# Altium schematic sheet ARK_RTK_Base.SchDoc(ARK_RTK_Base)
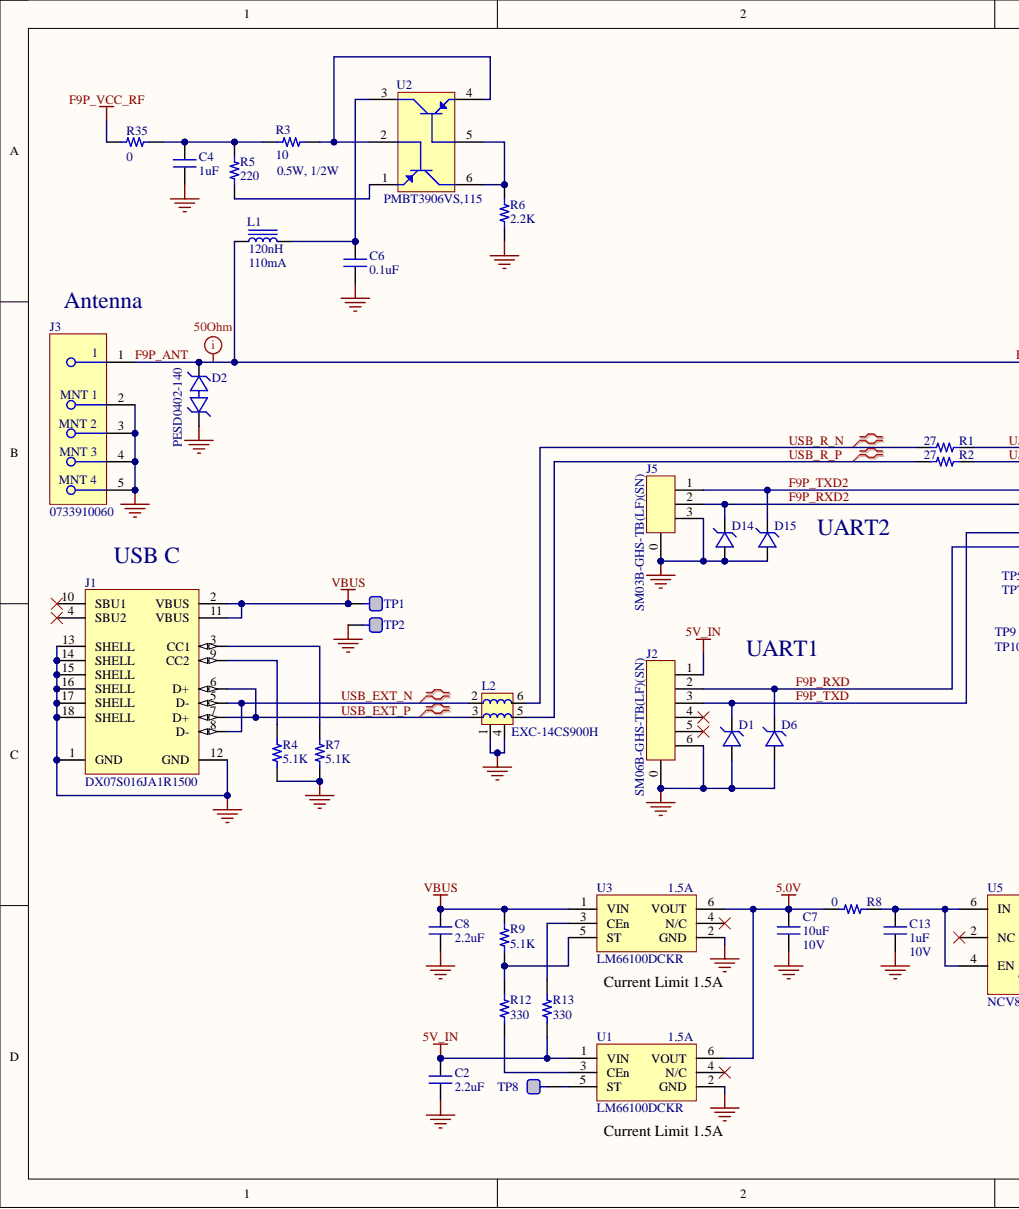
[diagram p0: ARK_RTK_Base.SchDoc, left]
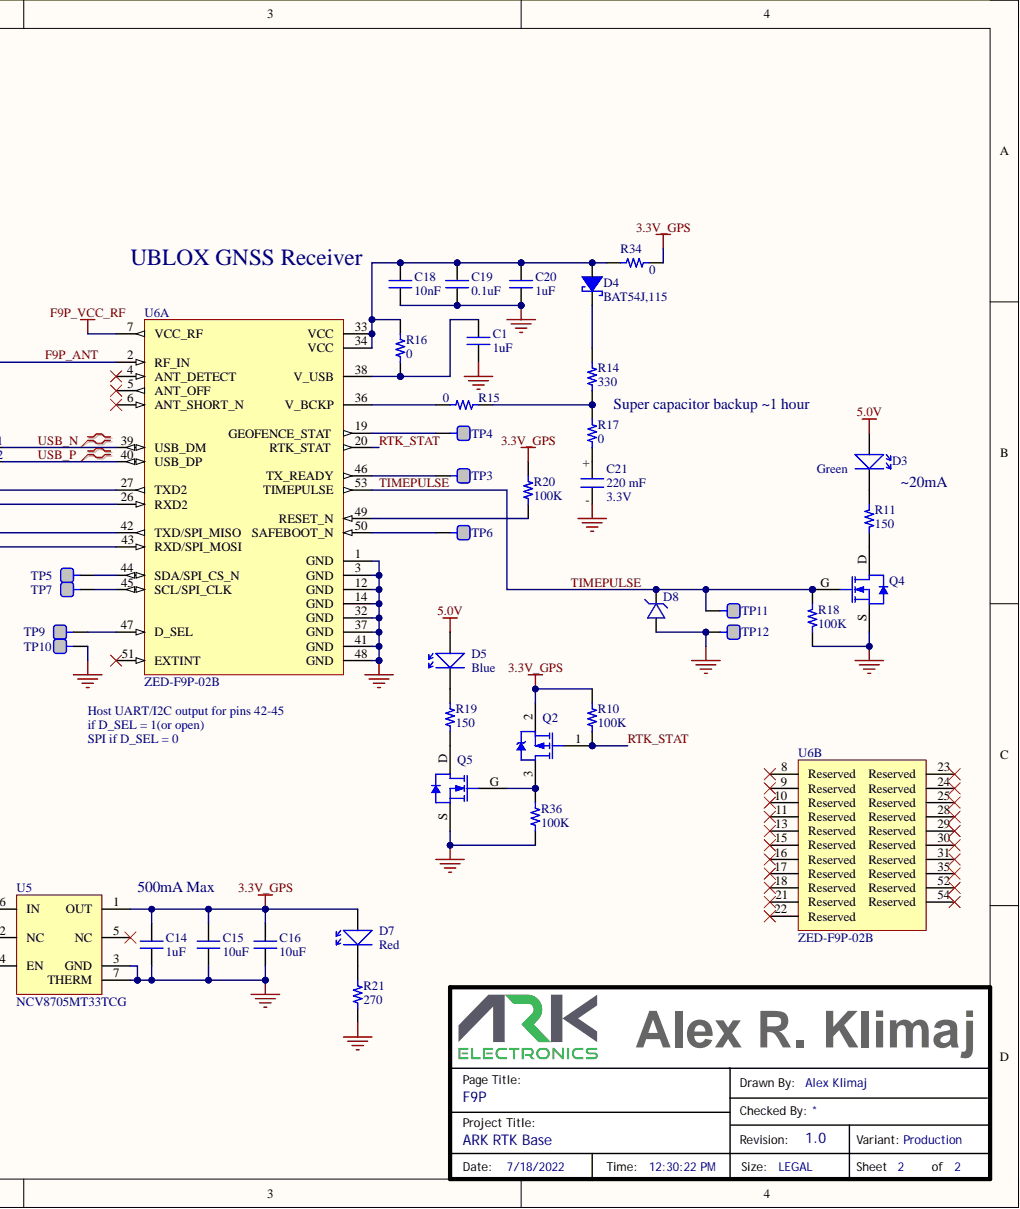
[diagram p1: ARK_RTK_Base.SchDoc, right]

## Parts
C1  1uF  [CAP 0402/1005]
C2  2.2uF  [CAP 0402/1005]
C4  1uF  [CAP 0402/1005]
C6  value 0.1uF  [CAP 0402/1005]  (hidden comment: Capacitor)
C7  10uF  Voltage_Rating: 10V  [CAP 0402/1005]
C8  2.2uF  [CAP 0402/1005]
C13  1uF  Voltage_Rating: 10V  [CAP 0402/1005]
C14  1uF  [CAP 0402/1005]
C15  10uF  [CAP 0402/1005]
C16  10uF  [CAP 0402/1005]
C18  10nF  [CAP 0402/1005]
C19  value 0.1uF  [CAP 0402/1005]  (hidden comment: Capacitor)
C20  1uF  [CAP 0402/1005]
C21  220 mF  Voltage_Rating: 3.3V  [DSK-3R3H224U-HL]
D1  TVS Diode  [SOD-923]  (value not drawn)
D2  PESD0402-140  [DIOC1005X04N 0402]
D3  LTST-C191KGKT  [LTST-C191TBKT]  (value not drawn)
D4  BAT54J,115  [SOD323]
D5  LTST-C194TBKT  [LTST-C191TBKT]  (value not drawn)
D6  TVS Diode  [SOD-923]  (value not drawn)
D7  LTST-C190KRKT  [LTST-C191TBKT]  (value not drawn)
D8  TVS Diode  [SOD-923]  (value not drawn)
D14  TVS Diode  [SOD-923]  (value not drawn)
D15  TVS Diode  [SOD-923]  (value not drawn)
J1  DX07S016JA1R1500  [CQ-USB-C16PSMT0]
J2  value SM06B-GHS-TB(LF)(SN)  Mfr Part Number: SM06B-GHS-TB(LF)(SN)  [SM06B-GHS]
J3  0733910060  [MOLEX_73391-0060]
J5  SM03B-GHS-TB(LF)(SN)  [SM03B-GHS]
L1  120nH  Current_Rating: 110mA  [Ind 0402/1005]
L2  EXC-14CS900H  [EXC-14CS900H]
Q2  INF-PEMOS-GSD-3-B  [INF-PG-SOT-323_L]  (value not drawn)
Q4  DMN53D0L-7  [SOT23 DIODES]  (value not drawn)
Q5  DMN53D0L-7  [SOT23 DIODES]  (value not drawn)
R1  27  [RESC0402(1005)_N]
R2  27  [RESC0402(1005)_N]
R3  10  Power_Rating: 0.5W, 1/2W  [C1210_M]
R4  value 5.1K  [RESC0402(1005)_N]  (hidden comment: Resistor)
R5  220  [RESC0402(1005)_N]
R6  2.2K  [RESC0402(1005)_N]
R7  value 5.1K  [RESC0402(1005)_N]  (hidden comment: Resistor)
R8  0  [RESC0402(1005)_N]
R9  value 5.1K  [RESC0402(1005)_N]  (hidden comment: Resistor)
R10  100K  [RESC0402(1005)_N]
R11  150  [RESC0402(1005)_N]
R12  value 330  [RESC0402(1005)_N]  (hidden comment: Resistor)
R13  value 330  [RESC0402(1005)_N]  (hidden comment: Resistor)
R14  330  [RESC0402(1005)_N]
R15  0  [RESC0402(1005)_N]
R16  0  [RESC0402(1005)_N]
R17  0  [RESC0402(1005)_N]
R18  100K  [RESC0402(1005)_N]
R19  150  [RESC0402(1005)_N]
R20  100K  [RESC0402(1005)_N]
R21  270  [RESC0402(1005)_N]
R34  0  [RESC0402(1005)_N]
R35  0  [RESC0402(1005)_N]
R36  100K  [RESC0402(1005)_N]
TP1  TEST_PAD  [TEST_PAD]  (value not drawn)  (no BOM)
TP2  TEST_PAD  [TEST_PAD]  (value not drawn)  (no BOM)
TP3  TEST_PAD  [TEST_PAD]  (value not drawn)  (no BOM)
TP4  TEST_PAD  [TEST_PAD]  (value not drawn)  (no BOM)
TP5  TEST_PAD  [TEST_PAD]  (value not drawn)  (no BOM)
TP6  TEST_PAD  [TEST_PAD]  (value not drawn)  (no BOM)
TP7  TEST_PAD  [TEST_PAD]  (value not drawn)  (no BOM)
TP8  TEST_PAD  [TEST_PAD]  (value not drawn)  (no BOM)
TP9  TEST_PAD  [TEST_PAD]  (value not drawn)  (no BOM)
TP10  TEST_PAD  [TEST_PAD]  (value not drawn)  (no BOM)
TP11  TEST_PAD  [TEST_POINT]  (value not drawn)  (no BOM)
TP12  TEST_PAD  [TEST_POINT]  (value not drawn)  (no BOM)
U1  LM66100  value LM66100DCKR  Current_Rating: 1.5A  Mfr Part Number: LM66100DCKR  [SOT65P210X110-6N]
U2  PMBT3906VS,115  [SOT666]
U3  LM66100  value LM66100DCKR  Current_Rating: 1.5A  Mfr Part Number: LM66100DCKR  [SOT65P210X110-6N]
U5  NCV8705MT33TCG  [WDFN6 2x2, 0.65P]
U6  ZED-F9P-02B  [Ublox ZED-F9P]  (parts A,B)

## Nets
3.3V_GPS: C14.2 C15.2 C16.2 D7.2 Q2.2 R10.2 R20.2 R34.1 U5.1(OUT)
5.0V: C2.2 C7.2 D3.2 D5.2 J2.1 R8.1 R13.2 U1.1(VIN) U1.6(VOUT) U3.6(VOUT)
F9P_VCC_RF: R35.1 U6.7(VCC_RF)
GND: C1.1 C2.1 C4.1 C6.1 C7.1 C8.1 C13.1 C14.1 C15.1 C16.1 C18.1 C19.1 C20.1 C21.- D1.2 D2.2 D6.2 D8.2 D14.2 D15.2 J1.1(GND) J1.12(GND) J1.13(SHELL) J1.14(SHELL) J1.15(SHELL) J1.16(SHELL) J1.17(SHELL) J1.18(SHELL) J2.0 J2.6 J3.2 J3.3 J3.4 J3.5 J5.0 J5.3 L2.1 L2.4 Q4.S Q5.S R4.2 R6.1 R7.2 R18.1 R21.1 R36.1 TP2.1 TP10.1 TP12.1 U1.2(GND) U3.2(GND) U5.3(GND) U5.7(THERM) U6.1(GND) U6.3(GND) U6.12(GND) U6.14(GND) U6.32(GND) U6.37(GND) U6.41(GND) U6.48(GND)
VBUS: C8.2 J1.2(VBUS) J1.11(VBUS) R9.1 TP1.1 U3.1(VIN)
F9P_ANT: D2.1 J3.1 L1.1 U6.2(RF_IN)
F9P_RXD: D6.1 J2.2 U6.43(RXD/SPI_MOSI)
F9P_RXD2: D14.1 J5.2 U6.26(RXD2)
F9P_TXD: D1.1 J2.3 U6.42(TXD/SPI_MISO)
F9P_TXD2: D15.1 J5.1 U6.27(TXD2)
RTK_STAT: Q2.1 R10.1 U6.20(RTK_STAT)
TIMEPULSE: D8.1 Q4.G R18.2 TP11.1 U6.53(TIMEPULSE)
USB_EXT_N: J1.5(D-) J1.8(D-) L2.2
USB_EXT_P: J1.6(D+) J1.7(D+) L2.3
USB_N: R1.2 U6.39(USB_DM)
USB_P: R2.2 U6.40(USB_DP)
USB_R_N: L2.6 R1.1
USB_R_P: L2.5 R2.1
NetC1_2: C1.2 R16.1 U6.38(V_USB)
NetC4_2: C4.2 R3.2 R5.2 R35.2
NetC6_2: C6.2 L1.2 U2.3
NetC13_2: C13.2 R8.2 U5.4(EN) U5.6(IN)
NetC18_2: C18.2 C19.2 C20.2 D4.2 R16.2 R34.2 U6.33(VCC) U6.34(VCC)
NetC21_+: C21.+ R17.1
NetD3_1: D3.1 R11.2
NetD4_1: D4.1 R14.2
NetD5_1: D5.1 R19.2
NetD7_1: D7.1 R21.2
NetJ1_3: J1.3(CC1) R7.1
NetJ1_9: J1.9(CC2) R4.1
NetQ2_3: Q2.3 Q5.G R36.2
NetQ4_D: Q4.D R11.1
NetQ5_D: Q5.D R19.1
NetR3_1: R3.1 U2.2 U2.4
NetR5_1: R5.1 U2.1
NetR6_2: R6.2 U2.5 U2.6
NetR9_2: R9.2 R12.1 U3.5(ST)
NetR12_2: R12.2 U1.3(CEn)
NetR13_1: R13.1 U3.3(CEn)
NetR14_1: R14.1 R15.2 R17.2
NetR15_1: R15.1 U6.36(V_BCKP)
NetR20_1: R20.1 U6.49(RESET_N)
NetTP3_1: TP3.1 U6.46(TX_READY)
NetTP4_1: TP4.1 U6.19(GEOFENCE_STAT)
NetTP5_1: TP5.1 U6.44(SDA/SPI_CS_N)
NetTP6_1: TP6.1 U6.50(SAFEBOOT_N)
NetTP7_1: TP7.1 U6.45(SCL/SPI_CLK)
NetTP8_1: TP8.1 U1.5(ST)
NetTP9_1: TP9.1 U6.47(D_SEL)

Not connected: J1 4(SBU2) 10(SBU1); J2 4 5; U1 4(N/C); U3 4(N/C); U5 2(NC) 5(NC); U6 4(ANT_DETECT) 5(ANT_OFF) 6(ANT_SHORT_N) 8(Reserved) 9(Reserved) 10(Reserved) 11(Reserved) 13(Reserved) 15(Reserved) 16(Reserved) 17(Reserved) 18(Reserved) 21(Reserved) 22(Reserved) 23(Reserved) 24(Reserved) 25(Reserved) 28(Reserved) 29(Reserved) 30(Reserved) 31(Reserved) 35(Reserved) 51(EXTINT) 52(Reserved) 54(Reserved)

## Library data of the parts
C1 C4 C14 C20 = 30652: SMT Capacitor; Manufacturer: Samsung Electro-Mechanics; Mfr Part Description: CAP CER 1uF ±10% 10V X5R 0402 (1005 Metric); Mfr Part Number: CL05A105KP5NNNC; Database Table Name: Parts; Dielectric: X5R; Tolerance: ±10%; Updated By: aklimaj; Voltage_Rating: 10V; Component Kind: Standard; ComponentLink1Description: Datasheet; ComponentLink1URL: http://www.samsungsem.com/kr/support/product-search/mlcc/CL05A105KP5NNNC.jsp; ComponentLink2Description: Supplier Part Details; ComponentLink2URL: https://www.digikey.com/product-detail/en/0/1276-1076-1-ND; Created_Date: 2019/11/21 13:38:55; ID: 30652; Library Name: Parts.DbLib; LifeCycle: New; Package_Case: 0402 (1005 Metric); Part_Type: Capacitor; RoHS: Yes; Supplier: Digi-Key; Supplier Part Number: 1276-1076-1-ND; Temperature Range: -55C to +85C; Updated_Date: 2020/08/31 12:38:02; Value: 1uF; Created By: aklimaj
C2 C8 = 30151: SMT Capacitor; Manufacturer: Murata; Manufacturer Alt 1: Taiyo Yuden; Mfr Part Description: CAP CER 2.2uF ±20% 10V X5R 0402 (1005 Metric); Mfr Part Number: GRM155R61A225ME95D; Mfr Part Number Alt 1: LMK105BJ225MV-F; Database Table Name: Parts; Dielectric: X5R; Tolerance: ±20%; Updated By: aklimaj; Voltage_Rating: 10V; Component Kind: Standard; ComponentLink1Description: Datasheet; ComponentLink1URL: http://search.murata.co.jp/Ceramy/image/img/A01X/partnumbering_e_01.pdf; ComponentLink2Description: Supplier Part Details; ComponentLink2URL: https://www.digikey.com/product-detail/en/murata-electronics-north-america/GRM155R61A225ME95D/490-10452-2-ND/5027537; Created_Date: 2017/11/02 14:41:38; ID: 30151; Library Name: Parts.DbLib; LifeCycle: New; Package_Case: 0402 (1005 Metric); Part_Type: Capacitor; RoHS: Yes; Supplier: Digi-Key; Supplier Part Number: 490-10452-2-ND; Temperature Range: -55C to +85C; Updated_Date: 2021/09/10 21:38:25; Value: 2.2uF; Created By: aklimaj
C6 C19 = 30007: SMT Capacitor; Manufacturer: Walsin Technology Corporation; Mfr Part Description: CAP CER 0.1uF ±10% 25V X5R 0402 (1005 Metric); Mfr Part Number: 0402X104K250CT; Database Table Name: Parts; Dielectric: X5R; Tolerance: ±10%; Updated By: aklimaj; Voltage_Rating: 25V; Component Kind: Standard; ComponentLink1Description: Datasheet; ComponentLink1URL: http://www.passivecomponent.com/wp-content/uploads/2018/10/MLCC.pdf; ComponentLink2Description: Supplier Part Details; ComponentLink2URL: https://www.digikey.com/products/en?keywords=0402X104K250CT; Created_Date: 2017/07/11 17:31:38; ID: 30007; Library Name: Parts.DbLib; LifeCycle: New; Package_Case: 0402 (1005 Metric); Part_Type: Capacitor; RoHS: Yes; Supplier: Digi-Key; Supplier Part Number: 1292-1640-2-ND; Temperature Range: -55C to +85C; Updated_Date: 2020/12/17 20:30:00; Created By: aklimaj
C7 = 30418: SMT Capacitor; Manufacturer: Samsung Electro-Mechanics; Mfr Part Description: CAP CER 10uF ±20% 10V X5R 0402 (1005 Metric); Mfr Part Number: CL05A106MP8NUB8; Mfr Part Number Alt 1: CL05A106MP5NUNC; Database Table Name: Parts; Dielectric: X5R; Tolerance: ±20%; Updated By: aklimaj; Component Kind: Standard; ComponentLink1Description: Datasheet; ComponentLink1URL: http://www.samsungsem.com/kr/support/product-search/mlcc/CL05A106MP8NUB8.jsp; ComponentLink2Description: Supplier Part Details; ComponentLink2URL: https://www.digikey.com/product-detail/en/samsung-electro-mechanics/CL05A106MP8NUB8/1276-6830-1-ND/5961689; Created_Date: 2019/04/03 13:12:18; ID: 30418; Library Name: Parts.DbLib; LifeCycle: New; Manufacturer Alt 1: Samsung Electro-Mechanics; Package_Case: 0402 (1005 Metric); Part_Type: Capacitor; RoHS: Yes; Supplier: Digi-Key; Supplier Part Number: 1276-6830-1-ND; Temperature Range: -55C to +85C; Updated_Date: 2021/07/05 13:25:11; Value: 10uF; Created By: aklimaj
C13 = 30652: SMT Capacitor; Manufacturer: Samsung Electro-Mechanics; Mfr Part Description: CAP CER 1uF ±10% 10V X5R 0402 (1005 Metric); Mfr Part Number: CL05A105KP5NNNC; Database Table Name: Parts; Dielectric: X5R; Tolerance: ±10%; Updated By: aklimaj; Component Kind: Standard; ComponentLink1Description: Datasheet; ComponentLink1URL: http://www.samsungsem.com/kr/support/product-search/mlcc/CL05A105KP5NNNC.jsp; ComponentLink2Description: Supplier Part Details; ComponentLink2URL: https://www.digikey.com/product-detail/en/0/1276-1076-1-ND; Created_Date: 2019/11/21 13:38:55; ID: 30652; Library Name: Parts.DbLib; LifeCycle: New; Package_Case: 0402 (1005 Metric); Part_Type: Capacitor; RoHS: Yes; Supplier: Digi-Key; Supplier Part Number: 1276-1076-1-ND; Temperature Range: -55C to +85C; Updated_Date: 2020/08/31 12:38:02; Value: 1uF; Created By: aklimaj
C15 C16 = 30418: SMT Capacitor; Manufacturer: Samsung Electro-Mechanics; Mfr Part Description: CAP CER 10uF ±20% 10V X5R 0402 (1005 Metric); Mfr Part Number: CL05A106MP8NUB8; Mfr Part Number Alt 1: CL05A106MP5NUNC; Database Table Name: Parts; Dielectric: X5R; Tolerance: ±20%; Updated By: aklimaj; Voltage_Rating: 10V; Component Kind: Standard; ComponentLink1Description: Datasheet; ComponentLink1URL: http://www.samsungsem.com/kr/support/product-search/mlcc/CL05A106MP8NUB8.jsp; ComponentLink2Description: Supplier Part Details; ComponentLink2URL: https://www.digikey.com/product-detail/en/samsung-electro-mechanics/CL05A106MP8NUB8/1276-6830-1-ND/5961689; Created_Date: 2019/04/03 13:12:18; ID: 30418; Library Name: Parts.DbLib; LifeCycle: New; Manufacturer Alt 1: Samsung Electro-Mechanics; Package_Case: 0402 (1005 Metric); Part_Type: Capacitor; RoHS: Yes; Supplier: Digi-Key; Supplier Part Number: 1276-6830-1-ND; Temperature Range: -55C to +85C; Updated_Date: 2021/07/05 13:25:11; Value: 10uF; Created By: aklimaj
C18 = 30018: SMT Capacitor; Manufacturer: Samsung; Manufacturer Alt 1: YAGEO; Mfr Part Description: CAP CER 10000pF ±10% 25V X7R 0402 (1005 Metric); Mfr Part Number: CL05B103KA5NNNC; Mfr Part Number Alt 1: CC0402KRX7R8BB103; Database Table Name: Parts; Dielectric: X7R; Tolerance: ±10%; Updated By: aklimaj; Voltage_Rating: 25V; Component Kind: Standard; ComponentLink1Description: Datasheet; ComponentLink1URL: http://www.samsungsem.com/kr/support/product-search/mlcc/__icsFiles/afieldfile/2016/08/18/S_CL05B103KA5NNNC.pdf; ComponentLink2Description: Supplier Part Details; ComponentLink2URL: https://www.digikey.com/product-detail/en/samsung-electro-mechanics-america-inc/CL05B103KA5NNNC/1276-1057-1-ND/3889143; Created_Date: 2017/07/13 10:07:08; ID: 30018; Library Name: Parts.DbLib; LifeCycle: New; Package_Case: 0402 (1005 Metric); Part_Type: Capacitor; RoHS: Yes; Supplier: Digi-Key; Supplier Part Number: 1276-1057-1-ND; Temperature Range: -55C to +125C; Updated_Date: 2021/09/15 13:17:34; Value: 10nF; Created By: aklimaj
C21 = 31092: Manufacturer: Elna America; Mfr Part Description: CAP 220 mF -20%, +80% 3.3V Coin, Wide Terminals - Opposite Sides; Part_Type: Capacitor; Database Table Name: Parts; Tolerance: -20%, +80%; Updated By: aklimaj; Component Kind: Standard; ComponentLink1Description: Datasheet; ComponentLink1URL: https://media.digikey.com/pdf/Data%20Sheets/Elna%20America%20Inc/DS,DSK.pdf; ComponentLink2Description: Supplier Part Details; ComponentLink2URL: https://www.digikey.com/en/products/detail/elna-america/DSK-3R3H224U-HL/970181?s=N4IgTCBcDaIGwAYAsBaAjAsD0oHIBEQBdAXyA; Created_Date: 2021/05/23 17:43:09; ID: 31092; Library Name: Parts.DbLib; LifeCycle: New; Mfr Part Number: DSK-3R3H224U-HL; Package_Case: Coin, Wide Terminals - Opposite Sides; RoHS: Yes; Supplier: Digi-Key; Supplier Part Number: 604-1020-2-ND; Temperature Range: -10C to +60C; Updated_Date: 2021/05/23 18:11:51; Value: 220 mF; Created By: aklimaj
D1 D6 D8 D14 D15 = 30166: Manufacturer: ON Semiconductor; Mfr Part Description: TVS DIODE 3.3VWM SOD923; Mfr Part Number: ESD9C3.3ST5G; Part_Type: Protection; Database Table Name: Parts; Updated By: aklimaj; Value: ESD9C3.3ST5G; Voltage_Rating: 3.3V (Max); Component Kind: Standard; ComponentLink1Description: Datasheet; ComponentLink1URL: http://www.onsemi.com/pub/Collateral/ESD9C3.3S-D.PDF; ComponentLink2Description: Supplier Part Details; ComponentLink2URL: https://www.digikey.com/product-detail/en/on-semiconductor/ESD9C3.3ST5G/ESD9C3.3ST5GOSCT-ND/1646498; Created_Date: 2017/12/13 10:54:56; Description: TVS Diode; ID: 30166; Library Name: Parts.DbLib; LifeCycle: New; Package_Case: SOD-923; RoHS: Yes; Supplier: Digi-Key; Supplier Part Number: ESD9C3.3ST5GOSCT-ND; Temperature Range: -55C to +150C (TJ); Updated_Date: 2018/10/19 21:38:12; Created By: aklimaj
D2 = 30446: TVS Diode; Manufacturer: Littelfuse Inc.; Mfr Part Description: TVS DIODE 14V 40V 0402; Part_Type: Protection; Database Table Name: Parts; Updated By: aklimaj; Voltage_Rating: 14V (Max); Component Kind: Standard; ComponentLink1Description: Datasheet; ComponentLink1URL: https://www.littelfuse.com/~/media/electronics/product_specifications/polymer_esd_suppressors/littelfuse_polymer_esd_suppressor_pesd0402_140_product_specification.pdf.pdf; ComponentLink2Description: Supplier Part Details; ComponentLink2URL: https://www.digikey.com/product-detail/en/littelfuse-inc/PESD0402-140/PESD0402-140CT-ND/1968526; Created_Date: 2019/05/07 17:26:56; ID: 30446; Library Name: Parts.DbLib; LifeCycle: New; Mfr Part Number: PESD0402-140; Package_Case: 0402 (1005 Metric); RoHS: Yes; Supplier: Digi-Key; Supplier Part Number: PESD0402-140CT-ND; Temperature Range: -55C to +125C (TJ); Updated_Date: 2019/11/30 16:11:35; Value: PESD0402-140; Created By: aklimaj
D3 = 30628: LTST-C191TBKT LED BLUE CLEAR 0603 SMD; Manufacturer: Lite-On Inc.; Manufacturer Alt 1: Wurth; Mfr Part Description: Green 571nm LED Indication - Discrete 2V 0603 (1608 Metric); Mfr Part Number Alt 1: 150060VS75000; Part_Type: Discrete; Current_Rating: 20mA; Database Table Name: Parts; Updated By: aklimaj; Voltage_Rating: 2V; Component Kind: Standard; ComponentLink1Description: Datasheet; ComponentLink1URL: http://optoelectronics.liteon.com/upload/download/DS22-2000-228/LTST-C191KGKT.PDF; ComponentLink2Description: Supplier Part Details; ComponentLink2URL: https://www.digikey.com/product-detail/en/lite-on-inc/LTST-C191KGKT/160-1446-1-ND/386834; Created_Date: 2019/11/13 17:03:39; ID: 30628; Library Name: Parts.DbLib; LifeCycle: New; Mfr Part Number: LTST-C191KGKT; Package_Case: 0603 (1608 Metric); RoHS: Yes; Supplier: Digi-Key; Supplier Part Number: 160-1446-1-ND; Updated_Date: 2021/03/27 12:39:10; Value: LTST-C191KGKT; Created By: aklimaj
D4 = 30022: Diode Schottky 30V 200mA (DC) Surface Mount SOD-323F; Manufacturer: Nexperia USA Inc.; Mfr Part Description: DIODE SCHOTTKY 30V 200MA SOD323F; Part_Type: Discrete; Capacitance @ Vr, F: 10pF @ 1V, 1MHz; Current - Reverse Leakage @ Vr: 2µA @ 25V; Database Table Name: Parts; Speed: Small Signal =< 200mA (Io), Any Speed; Updated By: aklimaj; Voltage - Forward (Vf) (Max) @ If: 800mV @ 100mA; Category: Discrete Semiconductor Products; Component Kind: Standard; ComponentLink1Description: Datasheet; ComponentLink1URL: http://assets.nexperia.com/documents/data-sheet/BAT54J.pdf; ComponentLink2Description: Supplier Part Details; ComponentLink2URL: https://www.digikey.com/products/en?keywords=1727-4818-6-ND&stock=1; Created_Date: 2017/07/13 11:14:24; Current - Average Rectified (Io): 200mA (DC); Current_Rating: 200mA (DC); Diode Type: Schottky; ID: 30022; Library Name: Parts.DbLib; LifeCycle: New; Manufacturer Part Number: BAT54J,115; Mfr Part Number: BAT54J,115; Mounting Type: Surface Mount; Operating Temperature - Junction: 150°C (Max); Package / Case: SC-90, SOD-323F; Package_Case: SC-90, SOD-323F; Packaging: Digi-Reel®; Part Status: Active; Pricing 1: 1=0.37, 10=0.273, 100=0.1545, 500=0.1023, 1000=0.07843 (USD); RoHS: Yes; Stock 1: 25340; Supplier: Digi-Key; Supplier 1: Digi-Key; Supplier Device Package: SOD-323F; Supplier Part Number: 1727-4818-6-ND; Supplier Part Number 1: 1727-4818-6-ND; Temperature Range: 150C (Max); Updated_Date: 2017/07/13 11:17:36; Value: BAT54J,115; Voltage - DC Reverse (Vr) (Max): 30V; Voltage_Rating: 30V; Created By: aklimaj
D5 = 30059: LTST-C191TBKT LED BLUE CLEAR 0603 SMD; Manufacturer: Lite-On Inc.; Mfr Part Description: LED BLUE CLEAR SMD; Part_Type: Discrete; Current_Rating: 20mA; Database Table Name: Parts; Notes: Was Mfr P/N LTST-C191TBKT; Updated By: aklimaj; Voltage_Rating: 3.3V; Component Kind: Standard; ComponentLink1Description: Datasheet; ComponentLink1URL: https://optoelectronics.liteon.com/upload/download/DS22-2010-0025/LTST-C194TBKT.PDF; ComponentLink2Description: Supplier Part Details; ComponentLink2URL: https://www.digikey.com/products/en?keywords=LTST-C194TBKT; Created_Date: 2017/09/05 17:40:57; ID: 30059; Library Name: Parts.DbLib; LifeCycle: New; Mfr Part Number: LTST-C194TBKT; Package_Case: 0603 (1608 Metric); RoHS: Yes; Supplier: Digi-Key; Supplier Part Number: 160-1837-2-ND; Updated_Date: 2021/09/10 21:26:14; Value: LTST-C194TBKT; Created By: aklimaj
D7 = 30943: Manufacturer: Lite-On Inc.; Mfr Part Description: LED RED CLEAR CHIP SMD; Part_Type: Discrete; Current_Rating: 20mA; Database Table Name: Parts; Updated By: aklimaj; Voltage_Rating: 2V; Component Kind: Standard; ComponentLink1Description: Datasheet; ComponentLink1URL: http://optoelectronics.liteon.com/upload/download/DS-22-99-0151/LTST-C190KRKT.pdf; ComponentLink2Description: Supplier Part Details; ComponentLink2URL: https://www.digikey.com/products/en?keywords=LTST-C190KRKT; Created_Date: 2020/12/11 17:10:57; ID: 30943; Library Name: Parts.DbLib; LifeCycle: New; Mfr Part Number: LTST-C190KRKT; Package_Case: 0603 (1608 Metric); RoHS: Yes; Supplier: Digi-Key; Supplier Part Number: 160-1436-2-ND; Updated_Date: 2020/12/11 17:11:02; Value: LTST-C190KRKT; Created By: aklimaj
J1 = 30730: Manufacturer: JAE Electronics; Mfr Part Description: USB Connectors USB Type C Rcptcl 16-Pin SMT; Part_Type: Connector; Current_Rating: 1.25A; Database Table Name: Parts; Updated By: aklimaj; Voltage_Rating: 20V; Component Kind: Standard; ComponentLink1Description: Datasheet; ComponentLink1URL: https://www.mouser.com/datasheet/2/206/JAE_Electronics_01282020_DX07-1729578.pdf; ComponentLink2Description: Supplier Part Details; ComponentLink2URL: https://www.mouser.com/ProductDetail/JAE-Electronics/DX07S016JA1R1500?qs=sGAEpiMZZMulM8LPOQ%252Byk5FYA0iiKW8NcI5hXCD5ObJK%2F8%252BarbnFOA%3D%3D; Created_Date: 2020/03/06 17:19:40; ID: 30730; Library Name: Parts.DbLib; LifeCycle: New; Mfr Part Number: DX07S016JA1R1500; RoHS: Yes; Supplier: Mouser; Supplier Part Number: 656-DX07S016JA1R1500; Temperature Range: -40C to +85C; Updated_Date: 2020/03/06 17:51:24; Value: DX07S016JA1R1500; Created By: aklimaj
J2 = 30041: CONN HEADER GH SIDE 6POS 1.25MM; Manufacturer: JST Sales America Inc.; Part_Type: Connector; Current_Rating: 1A; Database Table Name: Parts; Updated By: aklimaj; Voltage_Rating: 50V; Component Kind: Standard; ComponentLink1Description: Datasheet; ComponentLink1URL: http://www.jst-mfg.com/product/pdf/eng/eGH.pdf; ComponentLink2Description: Supplier Part Details; ComponentLink2URL: https://www.digikey.com/product-detail/en/jst-sales-america-inc/SM06B-GHS-TB(LF)(SN)/455-1568-1-ND/807836; Created_Date: 2017/08/09 12:25:17; ID: 30041; Library Name: Parts.DbLib; LifeCycle: New; Mfr Part Description: CONN HEADER GH SIDE 6POS 1.25MM; RoHS: Yes; Supplier: Digi-Key; Supplier Part Number: 455-1568-1-ND; Temperature Range: -25C to +85C; Updated_Date: 2019/12/18 13:28:51; Created By: aklimaj
J3 = 31030: Manufacturer: Molex; Mfr Part Description: CONN SMA RCPT STR 50 OHM PCB; Part_Type: Connector; Database Table Name: Parts; Updated By: aklimaj; Voltage_Rating: 500 V; Component Kind: Standard; ComponentLink1Description: Datasheet; ComponentLink1URL: https://www.molex.com/pdm_docs/ps/PS-89675-346.pdf; ComponentLink2Description: Supplier Part Details; ComponentLink2URL: https://www.digikey.com/products/en?keywords=0733910060; Created_Date: 2021/04/01 12:03:55; ID: 31030; Library Name: Parts.DbLib; LifeCycle: New; Mfr Part Number: 0733910060; RoHS: Yes; Supplier: Digi-Key; Supplier Part Number: WM5543-ND; Temperature Range: -65C to +165C; Updated_Date: 2021/04/01 12:13:59; Value: 0733910060; Created By: aklimaj
J5 = 30548: Manufacturer: JST Sales America Inc.; Mfr Part Description: Connector Header Surface Mount, Right Angle 3 position 0.049" (1.25mm); Part_Type: Connector; Current_Rating: 1A; Database Table Name: Parts; Updated By: aklimaj; Voltage_Rating: 50V; Component Kind: Standard; ComponentLink1Description: Datasheet; ComponentLink1URL: http://www.jst-mfg.com/product/pdf/eng/eGH.pdf; ComponentLink2Description: Supplier Part Details; ComponentLink2URL: https://www.digikey.com/product-detail/en/jst-sales-america-inc/SM03B-GHS-TB-LF-SN/455-1565-1-ND/807833; Created_Date: 2019/10/09 17:19:18; ID: 30548; Library Name: Parts.DbLib; LifeCycle: New; Mfr Part Number: SM03B-GHS-TB(LF)(SN); RoHS: Yes; Supplier: Digi-Key; Supplier Part Number: 455-1565-1-ND; Temperature Range: -25C to +85C; Updated_Date: 2019/10/09 17:32:45; Value: SM03B-GHS-TB(LF)(SN); Created By: aklimaj
L1 = 30445: SMT Inductor; Manufacturer: Murata Electronics North America; Mfr Part Description: INDUCTOR 120nH ±5% 110mA 0402 (1005 Metric); Mfr Part Number: LQW15ANR12J00D; Database Table Name: Parts; Tolerance: ±5%; Updated By: aklimaj; Component Kind: Standard; ComponentLink1Description: Datasheet; ComponentLink1URL: https://search.murata.co.jp/Ceramy/image/img/P02/JELF243A-0050.pdf; ComponentLink2Description: Supplier Part Details; ComponentLink2URL: https://www.digikey.com/product-detail/en/murata-electronics-north-america/LQW15ANR12J00D/490-4091-1-ND/1016351; Created_Date: 2019/05/07 17:16:34; ID: 30445; Library Name: Parts.DbLib; LifeCycle: New; Package_Case: 0402 (1005 Metric); Part_Type: Inductor; RoHS: Yes; Supplier: Digi-Key; Supplier Part Number: 490-4091-1-ND; Temperature Range: -55C to +125C; Updated_Date: 2019/05/07 17:22:22; Value: 120nH; Created By: aklimaj
L2 = 30540: 2 Line Common Mode Choke Surface Mount 90 Ohms @ 100MHz 100mA DCR 3.3Ohm (Typ); Manufacturer: Panasonic Electronic Components; Mfr Part Number Alt 1: EXC-14CS350H; Part_Type: Filter; Database Table Name: Parts; Updated By: aklimaj; Voltage_Rating: 5V; Component Kind: Standard; ComponentLink1Description: Datasheet; ComponentLink1URL: https://industrial.panasonic.com/cdbs/www-data/pdf/AWE0000/AWE0000C291.pdf; ComponentLink2Description: Supplier Part Details; ComponentLink2URL: https://www.digikey.com/product-detail/en/panasonic-electronic-components/EXC-14CS900H/P19422CT-ND/6109200; Created_Date: 2019/09/29 14:50:11; Current_Rating: 100mA; ID: 30540; Library Name: Parts.DbLib; LifeCycle: New; Manufacturer Alt 1: Panasonic Electronic Components; Mfr Part Description: 2 Line Common Mode Choke Surface Mount 90 Ohms @ 100MHz 100mA DCR 3.3Ohm (Typ); Mfr Part Number: EXC-14CS900H; Package_Case: 0302 (0806 Metric); RoHS: Yes; Supplier: Digi-Key; Supplier Part Number: P19422CT-ND; Temperature Range: -40C to +85C; Updated_Date: 2021/03/19 10:04:24; Value: EXC-14CS900H; Created By: aklimaj
Q2 = 30108: Manufacturer: Infineon Technologies; Mfr Part Description: MOSFET P-CH 20V 390MA SOT-323; Mfr Part Number: BSS223PWH6327XTSA1; Part_Type: Discrete; Current_Rating: 390mA (Ta); Database Table Name: Parts; Updated By: aklimaj; Value: BSS223PWH6327XTSA1; Voltage_Rating: 20V; Component Kind: Standard; ComponentLink1Description: Datasheet; ComponentLink1URL: http://www.infineon.com/dgdl/Infineon-BSS223PW-DS-v01_05-en.pdf?fileId=db3a3043321e49940132481523b6245f; ComponentLink2Description: Supplier Part Details; ComponentLink2URL: https://www.digikey.com/products/en?keywords=BSS223PWH6327XTSA1CT-ND&stock=1; Created_Date: 2017/09/21 14:50:09; Description: INF-PEMOS-GSD-3-B; ID: 30108; Library Name: Parts.DbLib; LifeCycle: New; Package_Case: SC-70, SOT-323; RoHS: Yes; Supplier: Digi-Key; Supplier Part Number: BSS223PWH6327XTSA1CT-ND; Temperature Range: -55C to +150C (TJ); Updated_Date: 2019/12/11 11:26:00; Created By: aklimaj
Q4 Q5 = 31111: Manufacturer: Diodes Incorporated; Mfr Part Description: MOSFET N-CH 50V 500MA SOT23; Part_Type: Discrete; Current_Rating: 500mA (Ta); Database Table Name: Parts; Updated By: aklimaj; Voltage_Rating: 2.5V, 10V; Component Kind: Standard; ComponentLink1Description: Datasheet; ComponentLink1URL: https://www.diodes.com/assets/Datasheets/DMN53D0L.pdf; ComponentLink2Description: Supplier Part Details; ComponentLink2URL: https://www.digikey.com/en/products/detail/diodes-incorporated/DMN53D0L-7/4934983; Created_Date: 2021/07/13 17:36:24; ID: 31111; Library Name: Parts.DbLib; LifeCycle: New; Mfr Part Number: DMN53D0L-7; Package_Case: TO-236-3, SC-59, SOT-23-3; RoHS: Yes; Supplier: Digi-Key; Supplier Part Number: DMN53D0L-7DITR-ND; Temperature Range: -55C to +150C (TJ); Updated_Date: 2021/07/13 17:36:30; Value: DMN53D0L-7; Created By: aklimaj
R1 R2 = 31124: SMT Resistor; Manufacturer: Yageo; Mfr Part Description: RES 27 Ohm ±1% 1/16W 0402 (1005 Metric); Mfr Part Number: AC0402FR-0727RL; Database Table Name: Parts; Power_Rating: 0.063W, 1/16W; Temperature Coeff: ±100ppm/degC; Tolerance: ±1%; Updated By: aklimaj; Component Kind: Standard; ComponentLink1Description: Datasheet; ComponentLink1URL: https://www.yageo.com/upload/media/product/productsearch/datasheet/rchip/PYu-AC_51_RoHS_L_7.pdf; ComponentLink2Description: Supplier Part Details; ComponentLink2URL: https://www.digikey.com/en/products/detail/yageo/AC0402FR-0727RL/5895230; Created_Date: 2021/07/22 13:22:26; ID: 31124; Library Name: Parts.DbLib; LifeCycle: New; Package_Case: 0402 (1005 Metric); Part_Type: Resistor; RoHS: Yes; Supplier: Digi-Key; Supplier Part Number: YAG5278TR-ND; Temperature Range: -55C to +155C; Updated_Date: 2021/07/22 13:22:43; Value: 27; Created By: aklimaj
R3 = 30452: SMT Resistor; Manufacturer: Stackpole Electronics Inc; Mfr Part Description: RES 10 Ohm ±5% 1/2W 1210 (3225 Metric); Mfr Part Number: RMCF1210JT10R0; Database Table Name: Parts; Temperature Coeff: ±200ppm/degC; Tolerance: ±5%; Updated By: aklimaj; Component Kind: Standard; ComponentLink1Description: Datasheet; ComponentLink1URL: https://www.seielect.com/catalog/sei-rmcf_rmcp.pdf; ComponentLink2Description: Supplier Part Details; ComponentLink2URL: https://www.digikey.com/product-detail/en/stackpole-electronics-inc/RMCF1210JT10R0/RMCF1210JT10R0CT-ND/1942842; Created_Date: 2019/05/08 15:49:04; ID: 30452; Library Name: Parts.DbLib; LifeCycle: New; Package_Case: 1210 (3225 Metric); Part_Type: Resistor; RoHS: Yes; Supplier: Digi-Key; Supplier Part Number: RMCF1210JT10R0CT-ND; Temperature Range: -55C to +155C; Updated_Date: 2019/05/08 15:52:46; Value: 10; Created By: aklimaj
R4 R7 R9 = 30207: SMT Resistor; Manufacturer: Yageo; Mfr Part Description: RES 5.1K Ohm ±1% 1/16W 0402 (1005 Metric); Mfr Part Number: RC0402FR-075K1L; Database Table Name: Parts; Power_Rating: 0.063W, 1/16W; Temperature Coeff: ±100ppm/degC; Tolerance: ±1%; Updated By: aklimaj; Component Kind: Standard; ComponentLink1Description: Datasheet; ComponentLink1URL: http://www.yageo.com/documents/recent/PYu-RC_Group_51_RoHS_L_9.pdf; ComponentLink2Description: Supplier Part Details; ComponentLink2URL: https://www.digikey.com/product-detail/en/yageo/RC0402FR-075K1L/311-5.10KLRCT-ND/729571; Created_Date: 2018/05/21 18:16:47; ID: 30207; Library Name: Parts.DbLib; LifeCycle: New; Package_Case: 0402 (1005 Metric); Part_Type: Resistor; RoHS: Yes; Supplier: Digi-Key; Supplier Part Number: 311-5.10KLRCT-ND; Temperature Range: -55C to +155C; Updated_Date: 2018/10/19 21:38:02; Created By: aklimaj
R5 = 30490: SMT Resistor; Manufacturer: Stackpole Electronics Inc; Mfr Part Description: RES 220 Ohm ±5% 1/16W 0402 (1005 Metric); Mfr Part Number: RMCF0402JT220R; Database Table Name: Parts; Power_Rating: 0.063W, 1/16W; Temperature Coeff: ±100ppm/degC; Tolerance: ±5%; Updated By: aklimaj; Component Kind: Standard; ComponentLink1Description: Datasheet; ComponentLink1URL: https://www.seielect.com/catalog/sei-rmcf_rmcp.pdf; ComponentLink2Description: Supplier Part Details; ComponentLink2URL: https://www.digikey.com/product-detail/en/stackpole-electronics-inc/RMCF0402JT220R/RMCF0402JT220RCT-ND/2417855; Created_Date: 2019/07/03 12:15:03; ID: 30490; Library Name: Parts.DbLib; LifeCycle: New; Package_Case: 0402 (1005 Metric); Part_Type: Resistor; RoHS: Yes; Supplier: Digi-Key; Supplier Part Number: RMCF0402JT220RCT-ND; Temperature Range: -55C to +155C; Updated_Date: 2019/07/03 12:15:37; Value: 220; Created By: aklimaj
R6 = 30330: SMT Resistor; Manufacturer: Yageo; Mfr Part Description: RES 2.2K Ohm ±1% 1/16W 0402 (1005 Metric); Mfr Part Number: AC0402FR-072K2L; Database Table Name: Parts; Power_Rating: 0.063W, 1/16W; Temperature Coeff: ±100ppm/degC; Tolerance: ±1%; Updated By: aklimaj; Component Kind: Standard; ComponentLink1Description: Datasheet; ComponentLink1URL: http://www.yageo.com/NewPortal/yageodocoutput?fileName=/pdf/R-Chip/PYu-AC_51_RoHS_L_6.pdf; ComponentLink2Description: Supplier Part Details; ComponentLink2URL: https://www.digikey.com/products/en?keywords=YAG3467CT-ND&stock=1; Created_Date: 2019/02/28 18:47:29; ID: 30330; Library Name: Parts.DbLib; LifeCycle: New; Package_Case: 0402 (1005 Metric); Part_Type: Resistor; RoHS: Yes; Supplier: Digi-Key; Supplier Part Number: YAG3467CT-ND; Temperature Range: -55C to +155C; Updated_Date: 2019/02/28 18:47:44; Value: 2.2K; Created By: aklimaj
R8 R15-R17 R34 R35 = 30327: SMT Resistor; Manufacturer: YAGEO; Manufacturer Alt 1: Panasonic Electronic Components; Mfr Part Description: RES 0 Ohm Jumper 1/16W 0402 (1005 Metric); Mfr Part Number: AC0402JR-070RL; Mfr Part Number Alt 1: ERJ-2GE0R00X; Database Table Name: Parts; Power_Rating: 1/16W; Tolerance: Jumper; Updated By: aklimaj; Component Kind: Standard; ComponentLink1Description: Datasheet; ComponentLink1URL: https://www.yageo.com/upload/media/product/productsearch/datasheet/rchip/PYu-AC_51_RoHS_L_7.pdf; ComponentLink2Description: Supplier Part Details; ComponentLink2URL: https://www.digikey.com/products/en?keywords=AC0402JR-070RL; Created_Date: 2019/02/28 18:42:38; ID: 30327; Library Name: Parts.DbLib; LifeCycle: New; Manufacturer Alt 2: Stackpole Electronics Inc; Mfr Part Number Alt 2: RMCF0402ZT0R00; Package_Case: 0402 (1005 Metric); Part_Type: Resistor; RoHS: Yes; Supplier: Digi-Key; Supplier Part Number: 311-0.0LBTR-ND; Temperature Range: -55C to +155C; Updated_Date: 2021/12/03 21:01:50; Value: 0; Created By: aklimaj
R10 R18 R20 R36 = 30333: SMT Resistor; Manufacturer: YAGEO; Mfr Part Description: RES 100K Ohm ±5% 1/16W 0402 (1005 Metric); Mfr Part Number: AC0402JR-07100KL; Database Table Name: Parts; Power_Rating: 0.063W, 1/16W; Temperature Coeff: ±100ppm/degC; Tolerance: ±5%; Updated By: aklimaj; Component Kind: Standard; ComponentLink1Description: Datasheet; ComponentLink1URL: https://www.yageo.com/upload/media/product/productsearch/datasheet/rchip/PYu-AC_51_RoHS_L_7.pdf; ComponentLink2Description: Supplier Part Details; ComponentLink2URL: https://www.digikey.com/products/en?keywords=AC0402JR-07100KL; Created_Date: 2019/02/28 18:55:55; ID: 30333; Library Name: Parts.DbLib; LifeCycle: New; Package_Case: 0402 (1005 Metric); Part_Type: Resistor; RoHS: Yes; Supplier: Digi-Key; Supplier Part Number: YAG3515TR-ND; Temperature Range: -55C to +155C; Updated_Date: 2021/09/22 12:12:03; Value: 100K; Created By: aklimaj
R11 R19 = 30328: SMT Resistor; Manufacturer: Yageo; Mfr Part Description: RES 150 Ohm ±1% 1/16W 0402 (1005 Metric); Mfr Part Number: RC0402FR-07150RL; Database Table Name: Parts; Power_Rating: 0.063W, 1/16W; Temperature Coeff: ±100ppm/degC; Tolerance: ±1%; Updated By: aklimaj; Component Kind: Standard; ComponentLink1Description: Datasheet; ComponentLink1URL: https://www.yageo.com/upload/media/product/productsearch/datasheet/rchip/PYu-RC_Group_51_RoHS_L_11.pdf; ComponentLink2Description: Supplier Part Details; ComponentLink2URL: https://www.digikey.com/en/products/detail/yageo/RC0402FR-07150RL/726543; Created_Date: 2019/02/28 18:44:46; ID: 30328; Library Name: Parts.DbLib; LifeCycle: New; Package_Case: 0402 (1005 Metric); Part_Type: Resistor; RoHS: Yes; Supplier: Digi-Key; Supplier Part Number: 311-150LRTR-ND; Temperature Range: -55C to +155C; Updated_Date: 2021/05/26 09:40:11; Value: 150; Created By: aklimaj
R12-R14 = 30681: SMT Resistor; Manufacturer: Yageo; Mfr Part Description: RES 330 Ohm ±1% 1/16W 0402 (1005 Metric); Mfr Part Number: RC0402FR-07330RL; Database Table Name: Parts; Power_Rating: 0.063W, 1/16W; Temperature Coeff: ±100ppm/degC; Tolerance: ±1%; Updated By: aklimaj; Component Kind: Standard; ComponentLink1Description: Datasheet; ComponentLink1URL: https://www.yageo.com/upload/media/product/productsearch/datasheet/rchip/PYu-RC_Group_51_RoHS_L_10.pdf; ComponentLink2Description: Supplier Part Details; ComponentLink2URL: https://www.digikey.com/product-detail/en/yageo/RC0402FR-07330RL/311-330LRCT-ND/729541; Created_Date: 2019/12/02 20:26:12; ID: 30681; Library Name: Parts.DbLib; LifeCycle: New; Package_Case: 0402 (1005 Metric); Part_Type: Resistor; RoHS: Yes; Supplier: Digi-Key; Supplier Part Number: 311-330LRCT-ND; Temperature Range: -55C to +155C; Updated_Date: 2019/12/02 20:28:13; Created By: aklimaj
  R14: Value: 330
R21 = 30712: SMT Resistor; Manufacturer: Yageo; Mfr Part Description: RES 270 Ohm ±1% 1/16W 0402 (1005 Metric); Mfr Part Number: RC0402FR-07270RL; Database Table Name: Parts; Power_Rating: 0.063W, 1/16W; Temperature Coeff: ±100ppm/degC; Tolerance: ±1%; Updated By: aklimaj; Component Kind: Standard; ComponentLink1Description: Datasheet; ComponentLink1URL: https://www.yageo.com/upload/media/product/productsearch/datasheet/rchip/PYu-RC_Group_51_RoHS_L_10.pdf; ComponentLink2Description: Supplier Part Details; ComponentLink2URL: https://www.digikey.com/product-detail/en/yageo/RC0402FR-07270RL/311-270LRCT-ND/2827902; Created_Date: 2020/01/23 11:14:20; ID: 30712; Library Name: Parts.DbLib; LifeCycle: New; Package_Case: 0402 (1005 Metric); Part_Type: Resistor; RoHS: Yes; Supplier: Digi-Key; Supplier Part Number: 311-270LRCT-ND; Temperature Range: -55C to +155C; Updated_Date: 2020/01/23 11:14:46; Value: 270; Created By: aklimaj
TP1-TP12 = 30015: Mfr Part Description: Test Point; Database Table Name: Parts; Updated By: aklimaj; ComponentLink1Description: Datasheet; ComponentLink2Description: Supplier Part Details; Created_Date: 2017/07/13 09:26:35; Description: TEST_PAD; ID: 30015; Library Name: Parts.DbLib; LifeCycle: New; Part_Type: Test Point; RoHS: Yes; Updated_Date: 2019/07/19 12:21:04; Created By: aklimaj
U1 U3 = 30951: Manufacturer: Texas Instruments; Mfr Part Description: IC OR CTRLR IDEAL DIODE SC70-6; Part_Type: IC; Database Table Name: Parts; Updated By: aklimaj; Voltage_Rating: 5.5V; Component Kind: Standard; ComponentLink1Description: Datasheet; ComponentLink1URL: http://www.ti.com/general/docs/suppproductinfo.tsp?distId=10&amp;gotoUrl=http%3A%2F%2Fwww.ti.com%2Flit%2Fgpn%2flm66100; ComponentLink2Description: Supplier Part Details; ComponentLink2URL: https://www.digikey.com/products/en?keywords=LM66100DCKR; Created_Date: 2020/12/22 17:48:20; ID: 30951; Library Name: Parts.DbLib; LifeCycle: New; Package_Case: 6-TSSOP, SC-88, SOT-363; RoHS: Yes; Supplier: Digi-Key; Supplier Part Number: 296-53541-2-ND; Temperature Range: -40C to +105C; Updated_Date: 2020/12/22 17:55:00; Created By: aklimaj
U2 = 30489: Manufacturer: Nexperia USA Inc.; Mfr Part Description: TRANS 2PNP 40V 0.2A SOT666; Part_Type: Discrete; Current_Rating: 200mA; Database Table Name: Parts; Updated By: aklimaj; Voltage_Rating: 40V; Component Kind: Standard; ComponentLink1Description: Datasheet; ComponentLink1URL: https://assets.nexperia.com/documents/data-sheet/PMBT3906VS.pdf; ComponentLink2Description: Supplier Part Details; ComponentLink2URL: https://www.digikey.com/product-detail/en/nexperia-usa-inc/PMBT3906VS115/1727-5179-1-ND/2531777; Created_Date: 2019/07/03 12:00:32; ID: 30489; Library Name: Parts.DbLib; LifeCycle: New; Mfr Part Number: PMBT3906VS,115; Package_Case: SOT-563, SOT-666; RoHS: Yes; Supplier: Digi-Key; Supplier Part Number: 1727-5179-1-ND; Temperature Range: 150C (TJ); Updated_Date: 2019/07/03 12:00:46; Value: PMBT3906VS,115; Created By: aklimaj
U5 = 30451: Manufacturer: ON Semiconductor; Mfr Part Description: IC REG LINEAR 3.3V 500MA 6WDFN; Part_Type: IC; Database Table Name: Parts; Sub_Type: REG; Updated By: aklimaj; Component Kind: Standard; ComponentLink1Description: Datasheet; ComponentLink1URL: http://www.onsemi.com/pub/Collateral/NCV8705-D.PDF; ComponentLink2Description: Supplier Part Details; ComponentLink2URL: https://www.digikey.com/product-detail/en/on-semiconductor/NCV8705MT33TCG/NCV8705MT33TCGOSCT-ND/8538675; Created_Date: 2019/05/08 11:35:43; ID: 30451; Library Name: Parts.DbLib; LifeCycle: New; Mfr Part Number: NCV8705MT33TCG; Package_Case: 6-WDFN Exposed Pad; RoHS: Yes; Supplier: Digi-Key; Supplier Part Number: NCV8705MT33TCGOSCT-ND; Temperature Range: -40C to +125C (TJ); Updated_Date: 2019/05/08 11:48:17; Value: NCV8705MT33TCG; Created By: aklimaj
U6 = 30440: Manufacturer: U-Blox; Mfr Part Description: MOD RF RECEIVER GNSS 54LGA; Part_Type: MOD; Database Table Name: Parts; Notes: Was Mfr P/N ZED-F9P-00B-02; Updated By: aklimaj; Voltage_Rating: 2.7V ~ 3.6V; Component Kind: Standard; ComponentLink1Description: Datasheet; ComponentLink1URL: https://www.u-blox.com/sites/default/files/ZED-F9P_DataSheet_%28UBX-17051259%29.pdf; ComponentLink2Description: Supplier Part Details; ComponentLink2URL: https://www.digikey.com/products/en?keywords=ZED-F9P-02B; Created_Date: 2019/04/30 22:32:33; ID: 30440; Library Name: Parts.DbLib; LifeCycle: New; Mfr Part Number: ZED-F9P-02B; Package_Case: 54-SMD Module; RoHS: Yes; Supplier: Digi-Key; Supplier Part Number: 672-ZED-F9P-02BTR-ND; Temperature Range: -40C to +85C; Updated_Date: 2021/09/10 11:27:48; Value: ZED-F9P-02B; Created By: aklimaj
